# Altium schematic sheet ATmega328p.SchDoc(ATmega328p)
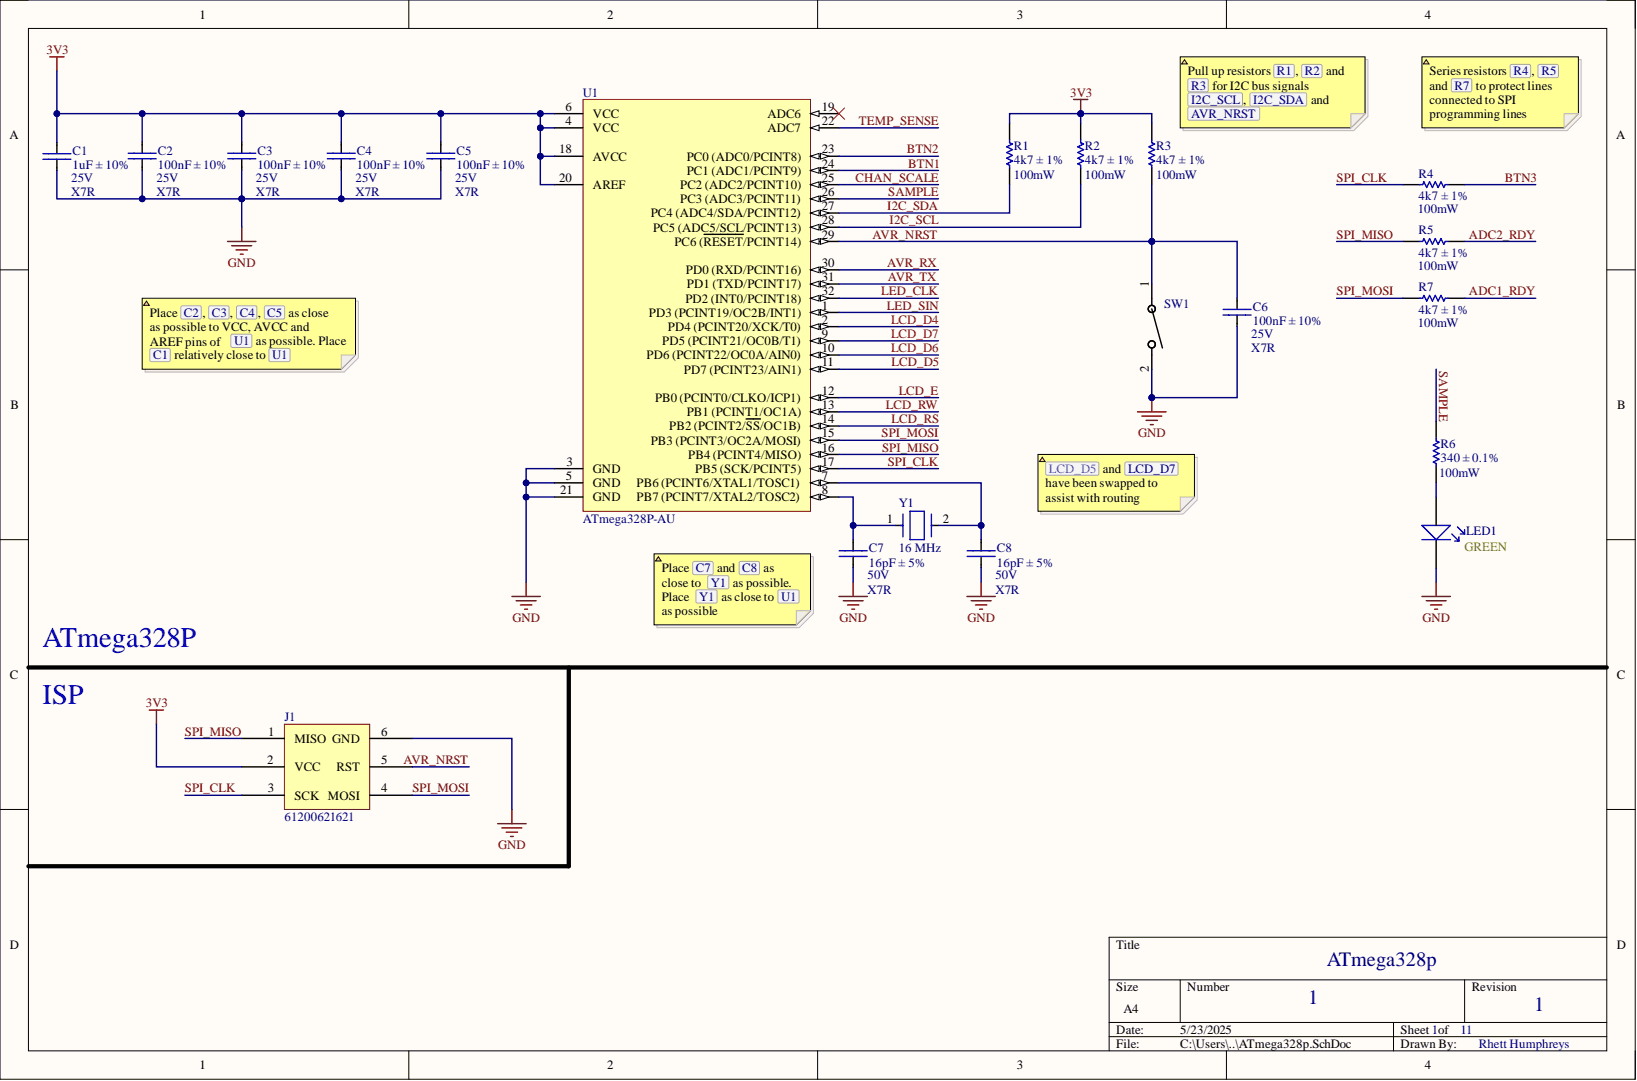
[diagram p0: ATmega328p.SchDoc]

## Parts
C1  1uF ± 10%  [CAP-0805]
C2  100nF ± 10%  [CAP-0603]
C3  100nF ± 10%  [CAP-0603]
C4  100nF ± 10%  [CAP-0603]
C5  100nF ± 10%  [CAP-0603]
C6  100nF ± 10%  [CAP-0603]
C7  16pF ± 5%  [CAP-0603]
C8  16pF ± 5%  [CAP-0603]
J1  61200621621  [61200621621]
LED1  (no value)  [0603 LED]
R1  4k7 ± 1%  [RES-0603]
R2  4k7 ± 1%  [RES-0603]
R3  4k7 ± 1%  [RES-0603]
R4  4k7 ± 1%  [RES-0603]
R5  4k7 ± 1%  [RES-0603]
R6  340 ± 0.1%  [RES-0603]
R7  4k7 ± 1%  [RES-0603]
SW1  PTS636SK43JSMTRLFS  [SW_PTS636S-J_CNK]  (value not drawn)
U1  ATmega328P-AU  [32A_M]
Y1  16 MHz  [FP-ABM7-MFG]

## Nets
3V3: C1.2 C2.2 C3.2 C4.2 C5.2 J1.2(VCC) R1.1 R2.1 R3.1 U1.4(VCC) U1.6(VCC) U1.18(AVCC) U1.20(AREF)
GND: C1.1 C2.1 C3.1 C4.1 C5.1 C6.1 C7.1 C8.1 J1.6(GND) LED1.2 SW1.2 U1.3(GND) U1.5(GND) U1.21(GND)
ADC1_RDY: R7.2
ADC2_RDY: R5.2
AVR_NRST: C6.2 J1.5(RST) R3.2 SW1.1 U1.29(PC6_(RESET/PCINT14))
AVR_RX: U1.30(PD0_(RXD/PCINT16))
AVR_TX: U1.31(PD1_(TXD/PCINT17))
BTN1: U1.24(PC1_(ADC1/PCINT9))
BTN2: U1.23(PC0_(ADC0/PCINT8))
BTN3: R4.2
CHAN_SCALE: U1.25
I2C_SCL: R2.2 U1.28(PC5_(ADC5/SCL/PCINT13))
I2C_SDA: R1.2 U1.27(PC4_(ADC4/SDA/PCINT12))
LCD_D4: U1.2(PD4_(PCINT20/XCK/T0))
LCD_D5: U1.11(PD7_(PCINT23/AIN1))
LCD_D6: U1.10(PD6_(PCINT22/OC0A/AIN0))
LCD_D7: U1.9(PD5_(PCINT21/OC0B/T1))
LCD_E: U1.12(PB0_(PCINT0/CLKO/ICP1))
LCD_RS: U1.14(PB2_(PCINT2/SS/OC1B))
LCD_RW: U1.13(PB1_(PCINT1/OC1A))
LED_CLK: U1.32(PD2_(INT0/PCINT18))
LED_SIN: U1.1(PD3_(PCINT19/OC2B/INT1))
SAMPLE: R6.1 U1.26(PC3_(ADC3/PCINT11))
SPI_CLK: J1.3(SCK) R4.1 U1.17(PB5_(SCK/PCINT5))
SPI_MISO: J1.1(MISO) R5.1 U1.16(PB4_(PCINT4/MISO))
SPI_MOSI: J1.4(MOSI) R7.1 U1.15(PB3_(PCINT3/OC2A/MOSI))
TEMP_SENSE: U1.22(ADC7)
NetC7_2: C7.2 U1.8 Y1.1
NetC8_2: C8.2 U1.7 Y1.2
NetLED1_1: LED1.1 R6.2

Not connected: U1 19(ADC6)

## Library data of the parts
C1 = CAP-0805: Capacitor chip unpolarized. 0603; Component Kind: Standard; Library Name: Master.SchLib
C2-C8 = CAP-0603: Capacitor chip unpolarized. 0603; Component Kind: Standard; Library Name: Master.SchLib
LED1 = 0603 LED: Component Kind: Standard; Library Name: Master.SchLib
R1-R7 = RES-0603: Resistor chip. 0603; Component Kind: Standard; Library Name: Master.SchLib
SW1 = PTS636SK43JSMTRLFS: No Description Available; Mfr_Name: C&K Components; Component Kind: Standard; Library Name: Master.SchLib; Type: Tactile Switches
U1 = CMP-0095-00269-2: 8-bit AVR Microcontroller, 32KB Flash, 1KB EEPROM, 2KB SRAM, 32-pin TQFP, Industrial Grade (-40°C to 85°C); Code_JEDEC: MS-026-ABA; Component Kind: Standard; ComponentLink1Description: Manufacturer URL; ComponentLink1URL: http://www.atmel.com/; ComponentLink2Description: ATmega48A/48PA/88A/88PA/168A/168PA/328/328P Datasheet; ComponentLink2URL: http://www.atmel.com/dyn/resources/prod_documents/doc8271.pdf; ComponentLink3Description: ATmega48A/48PA/88A/88PA/168A/168PA/328/328P Summary; ComponentLink3URL: http://www.atmel.com/dyn/resources/prod_documents/8271S.pdf; DatasheetVersion: revD, May-2011; Library Name: Altium Content Vault; PackageDescription: 32-Lead, 7x7x1.0 mm Body, 0.8mm Lead Pitch, Thin Profile Plastic Quad Flat Package (TQFP); PackageReference: 32A; PackageVersion: revC, Oct-2010; RevisionNote: DatasheetVersion & PackageVersion updated. URLs added.
Y1 = CMP-27762-000095-1: Crystal 16MHz ±20ppm 18pF SMD-2 6mm x 3.5mm; ESR (Equivalent Series Resistance): 50R; Frequency Stability: 30ppm; Case/Package: CSMD; Component Kind: Standard; Depth: 3.5mm; Frequency: 16MHz; Frequency Tolerance: 20ppm; Height: 0.055inch; Lead Free: Lead Free; Length: 6mm; Library Name: Altium Content Vault; Load Capacitance: 18pF; Max Operating Temperature: 85°C; Min Operating Temperature: -40°C; Mount: Surface Mount; Number of Pins: 2; Operating Frequency: 16 MHz; Package Quantity: 1000; Packaging: Tape and Reel; Radiation Hardening: No; Resistor Type: Ceramic; Series: ABM7; Termination: SMD/SMT; Tolerance: 20ppm; Width: 3.3mm
J1 = 61200621621: Component Kind: Standard; Library Name: Master.SchLib
